# Altium schematic sheet FPGA.SchDoc(FPGA)
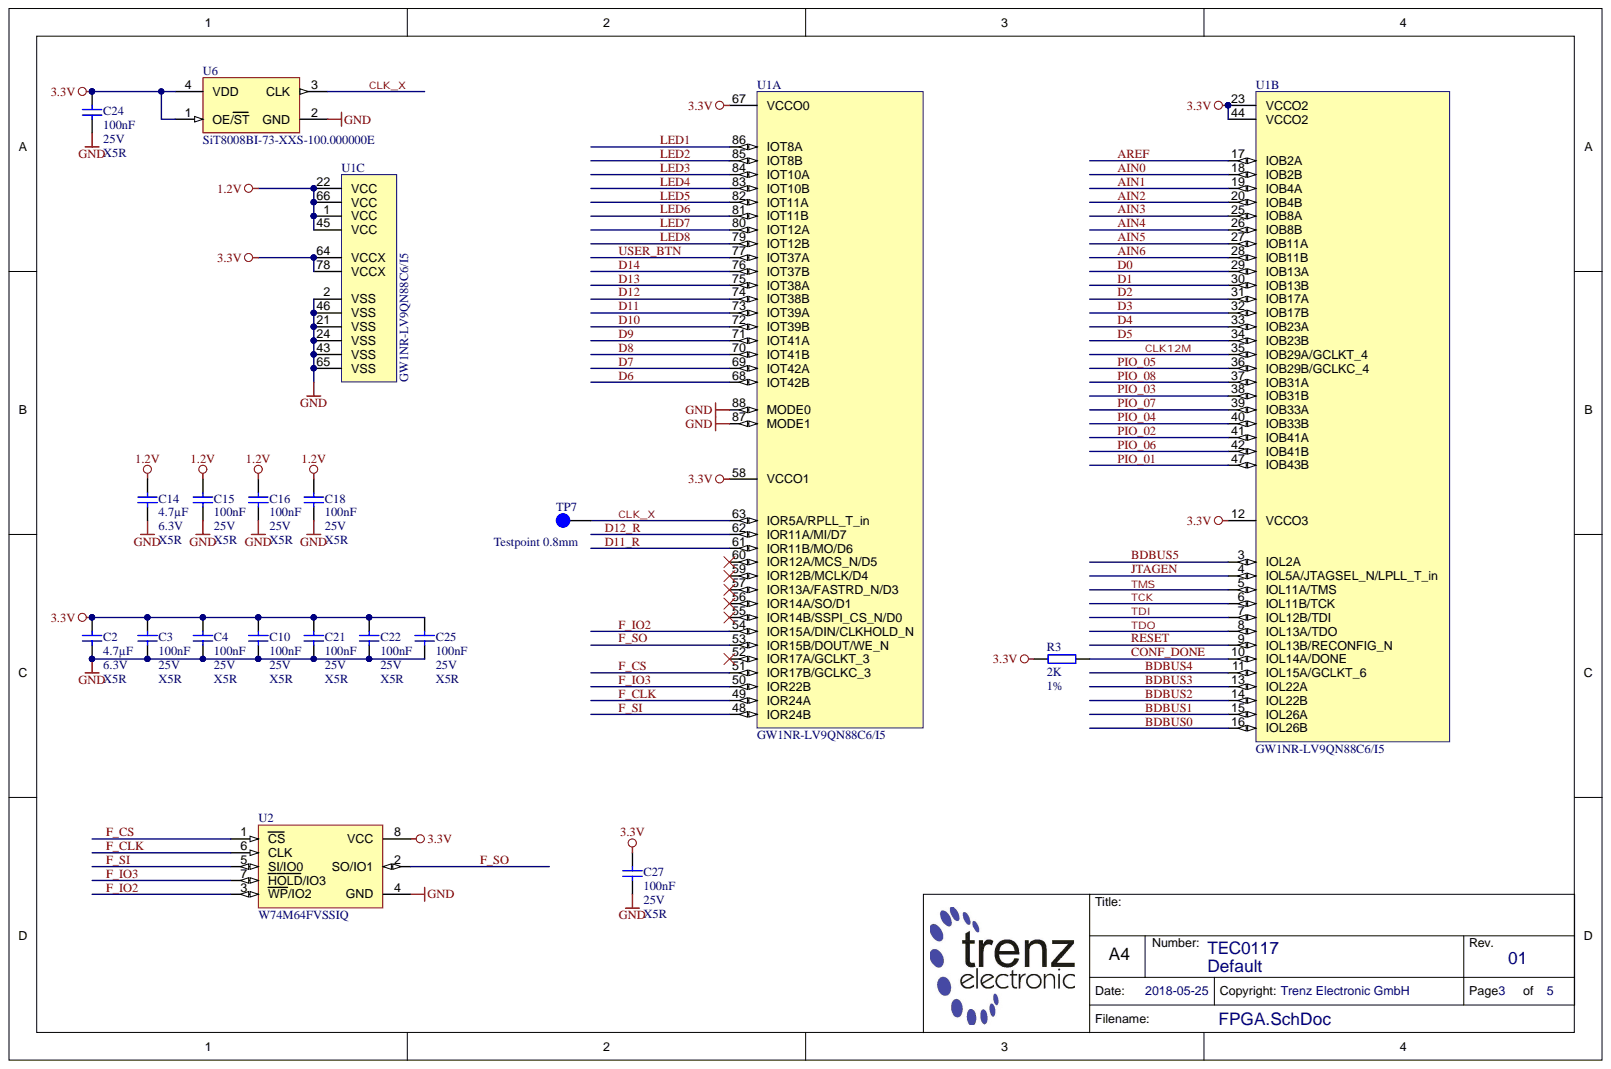
[diagram p0: FPGA.SchDoc]

## Parts
C2  4.7µF  Technology: X5R  Voltage: 6.3V  [CAPC1005X60N]
C3  100nF  Technology: X5R  Voltage: 25V  [CAPC1005X55N]
C4  100nF  Technology: X5R  Voltage: 25V  [CAPC1005X55N]
C10  100nF  Technology: X5R  Voltage: 25V  [CAPC1005X55N]
C14  4.7µF  Technology: X5R  Voltage: 6.3V  [CAPC1005X60N]
C15  100nF  Technology: X5R  Voltage: 25V  [CAPC1005X55N]
C16  100nF  Technology: X5R  Voltage: 25V  [CAPC1005X55N]
C18  100nF  Technology: X5R  Voltage: 25V  [CAPC1005X55N]
C21  100nF  Technology: X5R  Voltage: 25V  [CAPC1005X55N]
C22  100nF  Technology: X5R  Voltage: 25V  [CAPC1005X55N]
C24  100nF  Technology: X5R  Voltage: 25V  [CAPC1005X55N]
C25  100nF  Technology: X5R  Voltage: 25V  [CAPC1005X55N]
C27  100nF  Technology: X5R  Voltage: 25V  [CAPC1005X55N]
R3  2K  Tolerance: 1%  [RESC1005X40N]
TP7  Testpoint 0.8mm  [TP_0.8mm]
U1  GW1NR-LV9QN88C6/I5  [QFN40P1000X1000X90-89T674X674N]  (parts A,B,C)
U2  W74M64FVSSIQ  [SOIC127P790X216-8N]
U6  SiT8008BI-73-XXS-100.000000E  Database Table Name: CLK  [2016]

## Nets
1.2V: C14.1 C15.1 C16.1 C18.1 U1.1(VCC) U1.22(VCC) U1.45(VCC) U1.66(VCC)
3.3V: C2.1 C3.1 C4.1 C10.1 C21.1 C22.1 C24.1 C25.1 C27.1 R3.2 U1.12(VCCO3) U1.23(VCCO2) U1.44(VCCO2) U1.58(VCCO1) U1.64(VCCX) U1.67(VCCO0) U1.78(VCCX) U2.8(VCC) U6.1(OE/ST) U6.4(VDD)
GND: C2.2 C3.2 C4.2 C10.2 C14.2 C15.2 C16.2 C18.2 C21.2 C22.2 C24.2 C25.2 C27.2 U1.2(VSS) U1.21(VSS) U1.24(VSS) U1.43(VSS) U1.46(VSS) U1.65(VSS) U1.87(MODE1) U1.88(MODE0) U2.4(GND) U6.2(GND)
AIN0: U1.18(IOB2B)
AIN1: U1.19(IOB4A)
AIN2: U1.20(IOB4B)
AIN3: U1.25(IOB8A)
AIN4: U1.26(IOB8B)
AIN5: U1.27(IOB11A)
AIN6: U1.28(IOB11B)
AREF: U1.17(IOB2A)
BDBUS0: U1.16(IOL26B)
BDBUS1: U1.15(IOL26A)
BDBUS2: U1.14(IOL22B)
BDBUS3: U1.13(IOL22A)
BDBUS4: U1.11(IOL15A/GCLKT_6)
BDBUS5: U1.3(IOL2A)
CLK12M: U1.35(IOB29A/GCLKT_4)
CLK_X: TP7.1 U1.63(IOR5A/RPLL_T_in) U6.3(CLK)
CONF_DONE: R3.1 U1.10(IOL14A/DONE)
D0: U1.29(IOB13A)
D1: U1.30(IOB13B)
D2: U1.31(IOB17A)
D3: U1.32(IOB17B)
D4: U1.33(IOB23A)
D5: U1.34(IOB23B)
D6: U1.68(IOT42B)
D7: U1.69(IOT42A)
D8: U1.70(IOT41B)
D9: U1.71(IOT41A)
D10: U1.72(IOT39B)
D11: U1.73(IOT39A)
D11_R: U1.61(IOR11B/MO/D6)
D12: U1.74(IOT38B)
D12_R: U1.62(IOR11A/MI/D7)
D13: U1.75(IOT38A)
D14: U1.76(IOT37B)
F_CLK: U1.49(IOR24A) U2.6(CLK)
F_CS: U1.51(IOR17B/GCLKC_3) U2.1(CS)
F_IO2: U1.54(IOR15A/DIN/CLKHOLD_N) U2.3(WP/IO2)
F_IO3: U1.50(IOR22B) U2.7(HOLD/IO3)
F_SI: U1.48(IOR24B) U2.5(SI/IO0)
F_SO: U1.53(IOR15B/DOUT/WE_N) U2.2(SO/IO1)
JTAGEN: U1.4(IOL5A/JTAGSEL_N/LPLL_T_in)
LED1: U1.86(IOT8A)
LED2: U1.85(IOT8B)
LED3: U1.84(IOT10A)
LED4: U1.83(IOT10B)
LED5: U1.82(IOT11A)
LED6: U1.81(IOT11B)
LED7: U1.80(IOT12A)
LED8: U1.79(IOT12B)
PIO_01: U1.47(IOB43B)
PIO_02: U1.41(IOB41A)
PIO_03: U1.38(IOB31B)
PIO_04: U1.40(IOB33B)
PIO_05: U1.36(IOB29B/GCLKC_4)
PIO_06: U1.42(IOB41B)
PIO_07: U1.39(IOB33A)
PIO_08: U1.37(IOB31A)
RESET: U1.9(IOL13B/RECONFIG_N)
TCK: U1.6(IOL11B/TCK)
TDI: U1.7(IOL12B/TDI)
TDO: U1.8(IOL13A/TDO)
TMS: U1.5(IOL11A/TMS)
USER_BTN: U1.77(IOT37A)

Not connected: U1 52(IOR17A/GCLKT_3) 55(IOR14B/SSPI_CS_N/D0) 56(IOR14A/SO/D1) 57(IOR13A/FASTRD_N/D3) 59(IOR12B/MCLK/D4) 60(IOR12A/MCS_N/D5)

## Library data of the parts
C2 C14 = 24719: KON 4.7µF 6.3V X5R 20% SMD BF 1005 (0402); Database Table Name: C_1005_0402; Mixed: SMT; Preferred: Yes; Temperature-Range: -55/+85; Value: 4.7u; AN: 24719; Case: 1005 (0402); Component Kind: Standard; Library Name: TE.DbLib; Tolerance: 20%
C3 C4 C10 C15 C16 C18 C21 C22 C24 C25 C27 = 22472: KON 100nF 25V X5R ±10% SMD BF 1005 (0402); Database Table Name: C_1005_0402; Mixed: SMT; Preferred: Yes; Temperature-Range: -55/+85; Value: 100n; AN: 22472; Case: 1005 (0402); Component Kind: Standard; Library Name: TE.DbLib
R3 = 25073: WID SMD 2K 1% 0,063W  BF 1005 (0402); Database Table Name: R_1005_0402; H-ART: RC0402FR-072KL; Mixed: SMT; Preferred: Yes; AN: 25073; Case: 1005 (0402); Component Kind: Standard; Library Name: TE.DbLib; Technology: TK100; Value: 2K
TP7 = 26289: Database Table Name: PCB; Mixed: MECH; AN: 26289; Component Kind: Standard; Description: Testpoint 0.8mm; Library Name: TE.DbLib
U1 = 29053: Database Table Name: PROG_LOGIC; Mixed: SMT; AN: 29053; Case: QFN88; Component Kind: Standard; Description: GW1NR-LV9QN88C6/I5; H-ART: GW1NR-LV9QN88C6/I5; Library Name: TE.DbLib; HelpURL: b:\AD\adlib\datasheet\Littlebee\
U2 = 28247: Database Table Name: FLASH; Mixed: SMT; AN: 28247; Case: SO8W; Component Kind: Standard; Description: W74M64FVSSIQ; H-ART: W74M64FVSSIQ; Library Name: TE.DbLib; HelpURL: B:\ad\adlib\datasheet\W74M64FV
U6 = 25961: SiT8008BI-73-XXS-100.000000E MEMS Oszillator 100MHz; Mixed: SMT; Preferred: Yes; Value: 100M; AN: 25961; Case: 2.0  x 1.6mm; Component Kind: Standard; H-ART: SiT8008BI-73-XXS-100.000000E; Library Name: TE.DbLib; Trenz-Stock: Yes; HelpURL: B:\AD\adlib\datasheet\SiT8008
